# Altium schematic sheet POWER&STAMP_HOLE.SchDoc(POWER&STAMP_HOLE)
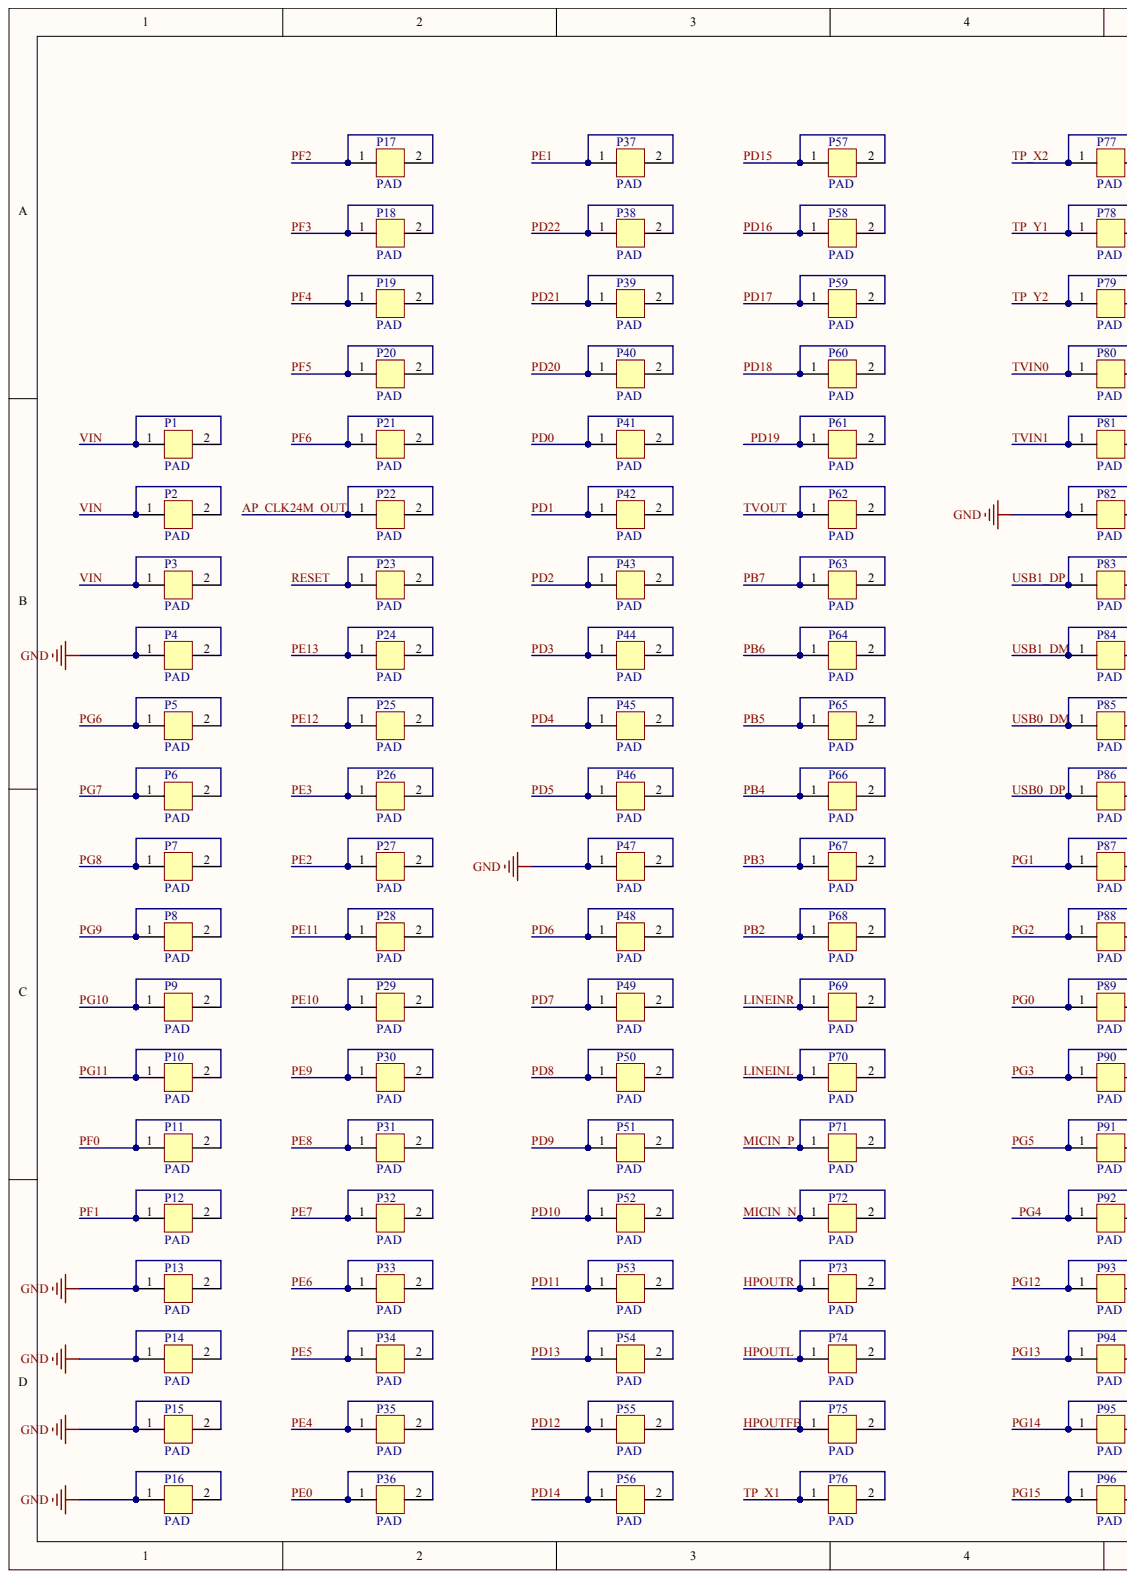
[diagram p0: POWER&STAMP_HOLE.SchDoc, left]
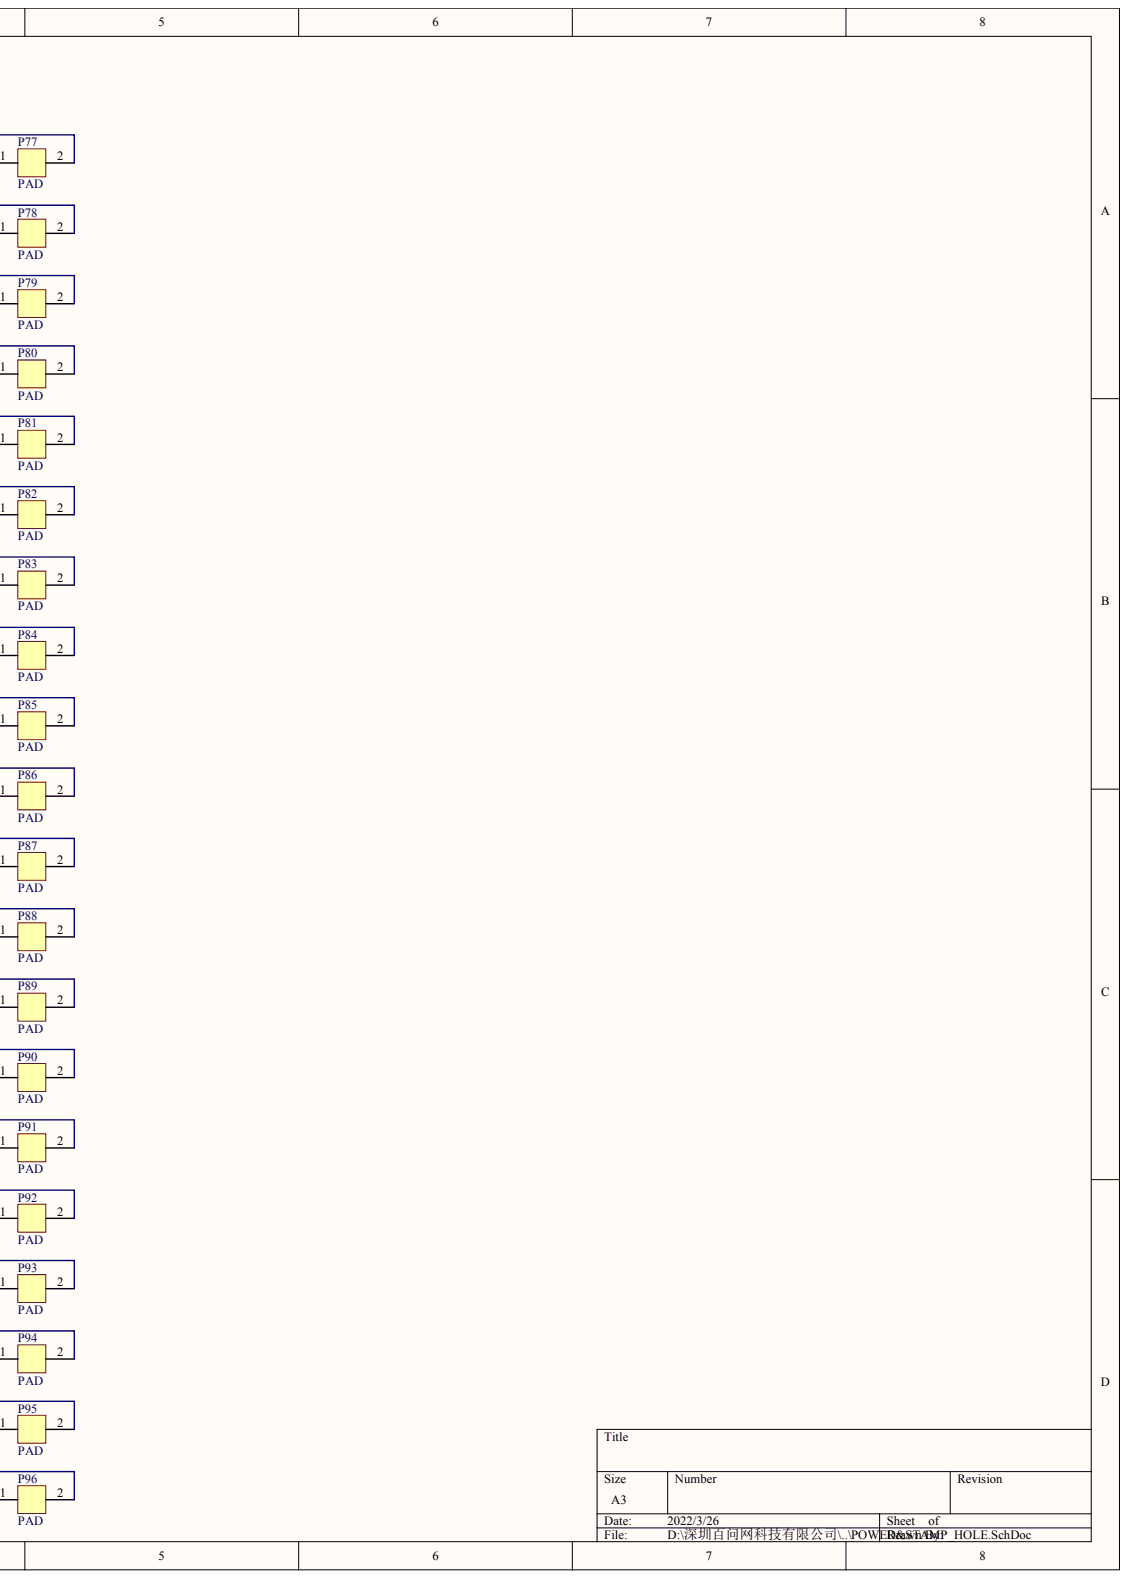
[diagram p1: POWER&STAMP_HOLE.SchDoc, right]

## Parts
C1  100nF  (value not drawn)
C2  1uF  (value not drawn)
C6  2.2uF  (value not drawn)
C8  100nF  (value not drawn)
C9  10uF  (value not drawn)
C10  10uF  (value not drawn)
C11  100nF  (value not drawn)
C13  100nF  (value not drawn)
C14  100nF  (value not drawn)
C16  10uF  (value not drawn)
C17  10uF  (value not drawn)
C18  10uF  (value not drawn)
C28  10uF  (value not drawn)
C29  100nF  (value not drawn)
C56  100nF  (value not drawn)
L1  2.2uH  (value not drawn)
L2  2.2uH  (value not drawn)
L3  2.2uH  (value not drawn)
LED1  GreenLED  (value not drawn)
P1  PAD
P2  PAD
P3  PAD
P4  PAD
P5  PAD
P6  PAD
P7  PAD
P8  PAD
P9  PAD
P10  PAD
P11  PAD
P12  PAD
P13  PAD
P14  PAD
P15  PAD
P16  PAD
P17  PAD
P18  PAD
P19  PAD
P20  PAD
P21  PAD
P22  PAD
P23  PAD
P24  PAD
P25  PAD
P26  PAD
P27  PAD
P28  PAD
P29  PAD
P30  PAD
P31  PAD
P32  PAD
P33  PAD
P34  PAD
P35  PAD
P36  PAD
P37  PAD
P38  PAD
P39  PAD
P40  PAD
P41  PAD
P42  PAD
P43  PAD
P44  PAD
P45  PAD
P46  PAD
P47  PAD
P48  PAD
P49  PAD
P50  PAD
P51  PAD
P52  PAD
P53  PAD
P54  PAD
P55  PAD
P56  PAD
P57  PAD
P58  PAD
P59  PAD
P60  PAD
P61  PAD
P62  PAD
P63  PAD
P64  PAD
P65  PAD
P66  PAD
P67  PAD
P68  PAD
P69  PAD
P70  PAD
P71  PAD
P72  PAD
P73  PAD
P74  PAD
P75  PAD
P76  PAD
P77  PAD
P78  PAD
P79  PAD
P80  PAD
P81  PAD
P82  PAD
P83  PAD
P84  PAD
P85  PAD
P86  PAD
P87  PAD
P88  PAD
P89  PAD
P90  PAD
P91  PAD
P92  PAD
P93  PAD
P94  PAD
P95  PAD
P96  PAD
R3  100K  (value not drawn)
R4  100K  (value not drawn)
R5  100K  (value not drawn)
R8  453K  (value not drawn)
R10  200K  (value not drawn)
R11  51K  (value not drawn)
R12  2K  (value not drawn)
R13  NC  (value not drawn)
R14  100K  (value not drawn)
R15  100K  (value not drawn)
R16  100K  (value not drawn)
R26  10K  (value not drawn)
R27  10K  (value not drawn)
R28  10K_NC  (value not drawn)
R32  10K_NC  (value not drawn)
R33  10K  (value not drawn)
R35  10K  (value not drawn)
R36  10K  (value not drawn)
U2  MX35LF1GE4AB-Z4I_C402160  (value not drawn)
U3  XC6206P182MR_C2904834  (value not drawn)
U4  EA3036  (value not drawn)

## Nets
AGND: C1.2 C2.2 C6.2 C8.2 U3.1
GND: C9.2 C10.2 C11.1 C13.2 C14.2 C16.2 C17.2 C18.2 C28.2 C29.1 C56.1 LED1.2 P4.1 P4.2 P13.1 P13.2 P14.1 P14.2 P15.1 P15.2 P16.1 P16.2 P47.1 P47.2 P82.1 P82.2 R3.1 R4.1 R5.1 R14.1 R28.2 R33.2 U2.4 U2.9 U4.1 U4.4 U4.5 U4.12 U4.13 U4.15 U4.17 U4.21
VCC_3V3: C1.1 C2.1 C10.1 C13.1 C29.2 L1.2 R8.1 R12.2 R15.2 R16.2 R26.1 R27.1 R32.1 R35.1 R36.1 U2.8 U3.3
VCC_CORE: C9.1 C11.2 L2.2 R11.1
VCC_DRAM: C28.1 C56.2 L3.2 R10.1
AP_CLK24M_OUT: P22.1 P22.2
AVCC_1V8: C6.1 C8.1 U3.2
HPOUTFB: P75.1 P75.2
HPOUTL: P74.1 P74.2
HPOUTR: P73.1 P73.2
LINEINL: P70.1 P70.2
LINEINR: P69.1 P69.2
MICIN_N: P72.1 P72.2
MICIN_P: P71.1 P71.2
PB2: P68.1 P68.2
PB3: P67.1 P67.2
PB4: P66.1 P66.2
PB5: P65.1 P65.2
PB6: P64.1 P64.2
PB7: P63.1 P63.2
PD0: P41.1 P41.2
PD1: P42.1 P42.2
PD2: P43.1 P43.2
PD3: P44.1 P44.2
PD4: P45.1 P45.2
PD5: P46.1 P46.2
PD6: P48.1 P48.2
PD7: P49.1 P49.2
PD8: P50.1 P50.2
PD9: P51.1 P51.2
PD10: P52.1 P52.2
PD11: P53.1 P53.2
PD12: P55.1 P55.2
PD13: P54.1 P54.2
PD14: P56.1 P56.2
PD15: P57.1 P57.2
PD16: P58.1 P58.2
PD17: P59.1 P59.2
PD18: P60.1 P60.2
PD19: P61.1 P61.2
PD20: P40.1 P40.2
PD21: P39.1 P39.2
PD22: P38.1 P38.2
PE0: P36.1 P36.2
PE1: P37.1 P37.2
PE2: P27.1 P27.2
PE3: P26.1 P26.2
PE4: P35.1 P35.2
PE5: P34.1 P34.2
PE6: P33.1 P33.2
PE7: P32.1 P32.2
PE8: P31.1 P31.2
PE9: P30.1 P30.2
PE10: P29.1 P29.2
PE11: P28.1 P28.2
PE12: P25.1 P25.2
PE13: P24.1 P24.2
PF0: P11.1 P11.2
PF1: P12.1 P12.2
PF2: P17.1 P17.2
PF3: P18.1 P18.2
PF4: P19.1 P19.2
PF5: P20.1 P20.2
PF6: P21.1 P21.2
PG0: P89.1 P89.2
PG1: P87.1 P87.2
PG2: P88.1 P88.2
PG3: P90.1 P90.2
PG4: P92.1 P92.2
PG5: P91.1 P91.2
PG6: P5.1 P5.2
PG7: P6.1 P6.2
PG8: P7.1 P7.2
PG9: P8.1 P8.2
PG10: P9.1 P9.2
PG11: P10.1 P10.2
PG12: P93.1 P93.2
PG13: P94.1 P94.2
PG14: P95.1 P95.2
PG15: P96.1 P96.2
RESET: P23.1 P23.2
SPI0_CLK: U2.6
SPI0_CS: R26.2 U2.1
SPI0_HOLD: R36.2 U2.7
SPI0_MISO: R27.2 R28.1 U2.2
SPI0_MOSI: R32.2 R33.1 U2.5
SPI0_WP: R35.2 U2.3
TP_X1: P76.1 P76.2
TP_X2: P77.1 P77.2
TP_Y1: P78.1 P78.2
TP_Y2: P79.1 P79.2
TVIN0: P80.1 P80.2
TVIN1: P81.1 P81.2
TVOUT: P62.1 P62.2
USB0_DM: P85.1 P85.2
USB0_DP: P86.1 P86.2
USB1_DM: P84.1 P84.2
USB1_DP: P83.1 P83.2
VIN: C16.1 C17.1 C18.1 P1.1 P1.2 P2.1 P2.2 P3.1 P3.2 U4.7 U4.9 U4.10 U4.16 U4.19
NetC14_1: C14.1 R13.1 R14.2 R16.1 U4.18
NetL1_1: L1.1 U4.11
NetL2_1: L2.1 U4.20
NetL3_1: L3.1 U4.6
NetLED1_1: LED1.1 R12.1
NetR3_2: R3.2 R8.2 U4.14
NetR4_2: R4.2 R10.2 U4.3
NetR5_2: R5.2 R11.2 U4.2
NetR13_2: R13.2 R15.1 U4.8

## Library data of the parts
C1 C2 C6 C8-C11 C13 C14 C16-C18 C28 C29 C56 = (no symbol name): PartNumber: CAP100NF; Published: 8-Jun-2000; LatestRevisionDate: 17-Jul-2002; LatestRevisionNote: Re-released for DXP Platform.; PackageReference: 0402; Publisher: Altium Limited
  C1 C8 C11 C13 C14 C29 C56: Value: 100nF
  C2: Value: 1uF
  C6: Value: 2.2uF
  C9 C10 C16-C18 C28: Value: 10uF
R3-R5 R8 R10-R16 R26-R28 R32 R33 R35 R36 = (no symbol name): PartNumber: RES2.2K; Published: 8-Jun-2000; LatestRevisionDate: 17-Jul-2002; LatestRevisionNote: Re-released for DXP Platform.; PackageReference: 0402; Publisher: Altium Limited
  R3-R5 R14-R16: Value: 100K
  R8: Value: 453K
  R10: Value: 200K
  R11: Value: 51K
  R12: Value: 2K
  R13: Value: NC
  R26 R27 R33 R35 R36: Value: 10K
  R28 R32: Value: 10K_NC
U2 = (no symbol name): BOM_Manufacturer: Macronix; Contributor: LCSC; BOM_Supplier: LCSC; BOM_Supplier Part: C402160; BOM_Manufacturer Part: MX35LF1GE4AB-Z4I; BOM_JLCPCB Part Class: Extended Part; spicePre: U; spiceSymbolName: MX35LF1GE4AB-Z4I_C402160
U3 = (no symbol name): Contributor: LCSC; BOM_Supplier: LCSC; BOM_Supplier Part: C2904834; BOM_Manufacturer Part: XC6206P182MR; spicePre: U; spiceSymbolName: XC6206P182MR_C2904834
L1-L3 LED1 P1-P96 U4 = (no symbol name)
  LED1: Published: 8-Jun-2000; LatestRevisionDate: 17-Jul-2002; LatestRevisionNote: Re-released for DXP Platform.; PackageVersion: Feb-2002; PackageReference: 3.2X1.6X1.1; PackageDescription: SM LED; 2 Flat Leads; Value: GreenLED; Publisher: Altium Limited
